# Altium schematic sheet Sheet2.SchDoc
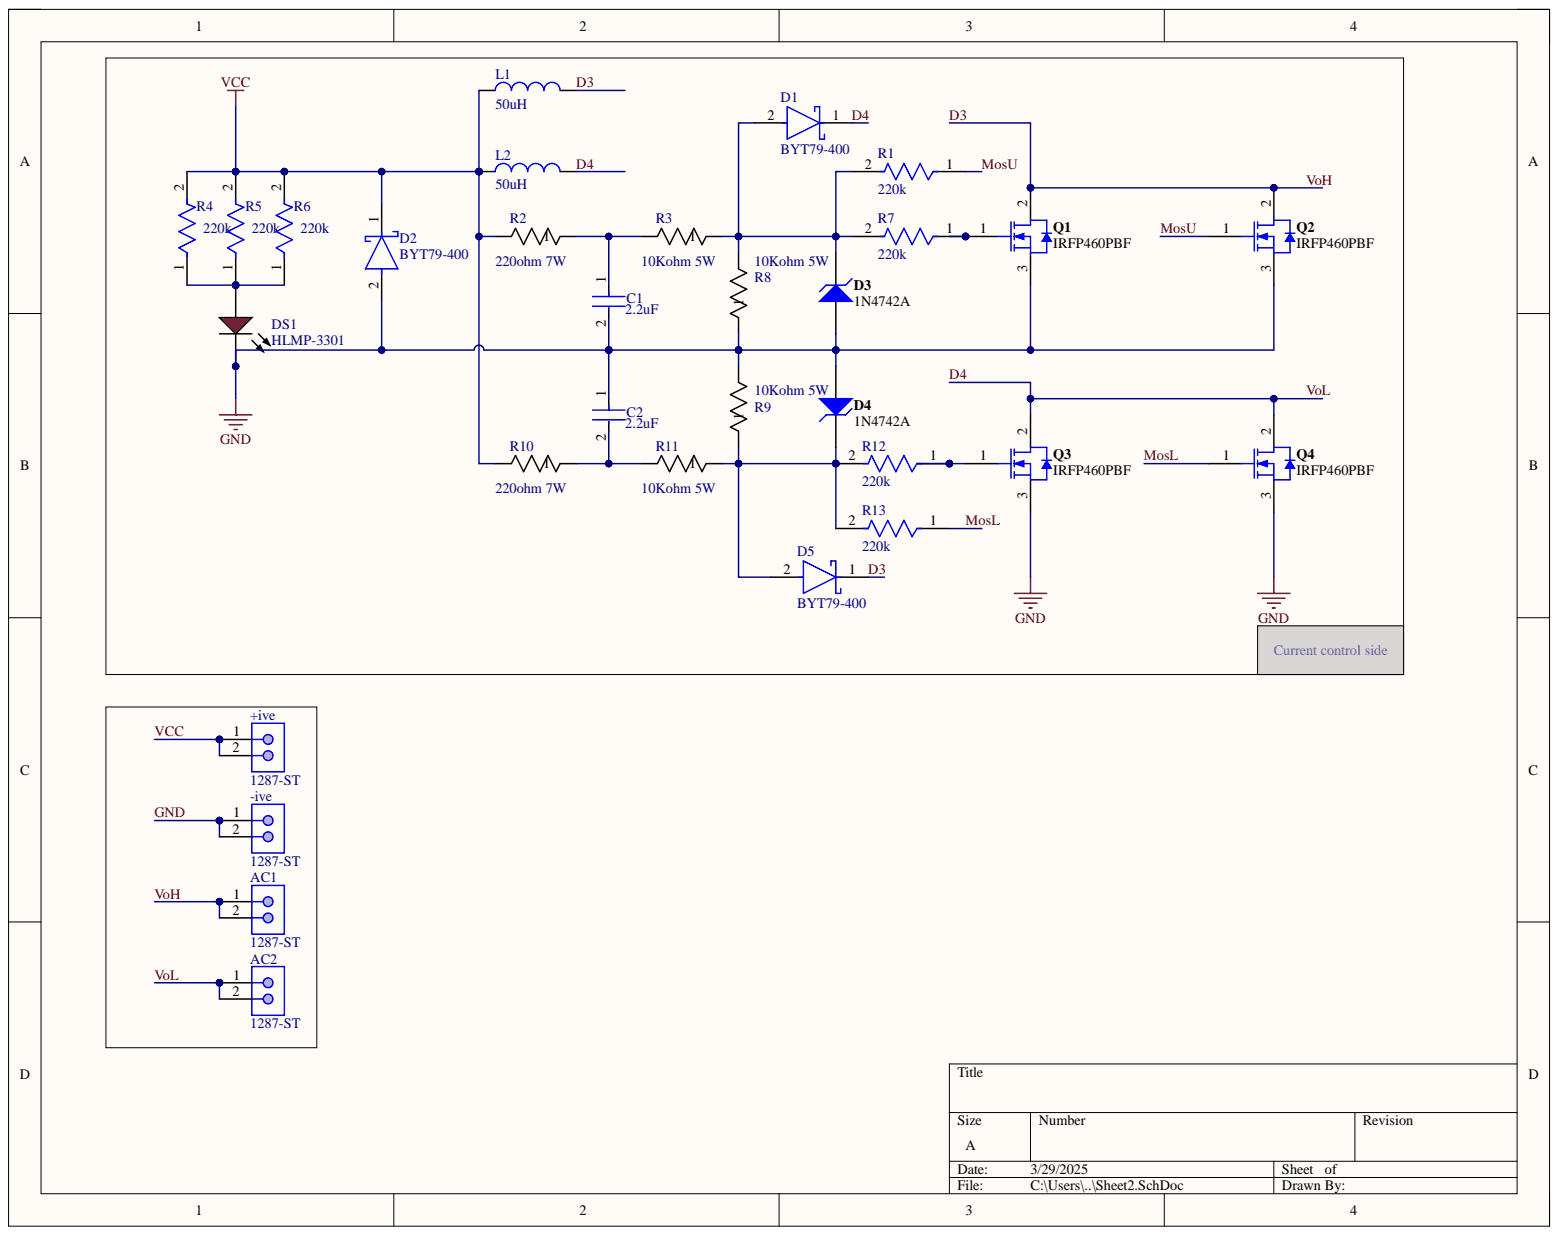
[diagram p0: Sheet2.SchDoc]

## Parts
+ive  1287-ST  [KSTN-1287-ST]
-ive  1287-ST  [KSTN-1287-ST]
AC1  1287-ST  [KSTN-1287-ST]
AC2  1287-ST  [KSTN-1287-ST]
C1  2.2uF  [FP-ECQ-E6223KF9-MFG]
C2  2.2uF  [FP-ECQ-E6223KF9-MFG]
D1  BYT79-400  [FP-TO-220-2-MFG]
D2  BYT79-400  [FP-TO-220-2-MFG]
D3  1N4742A  [FAIR-DO-41-2_V]
D4  1N4742A  [FAIR-DO-41-2_V]
D5  BYT79-400  [FP-TO-220-2-MFG]
DS1  HLMP-3301  [AVAG-HLMP-3301-2]
L1  50uH  [7447071]
L2  50uH  [7447071]
Q1  IRFP460PBF  [VISH-TO-247AC-3]
Q2  IRFP460PBF  [VISH-TO-247AC-3]
Q3  IRFP460PBF  [VISH-TO-247AC-3]
Q4  IRFP460PBF  [VISH-TO-247AC-3]
R1  220k  [FP-VR37-MFG]
R2  220ohm 7W  Max Power Dissipation: 7W  [RES_AC05_VIS]
R3  10Kohm 5W  Max Power Dissipation: 5W  [RES_AC05_VIS]
R4  220k  [FP-VR37-MFG]
R5  220k  [FP-VR37-MFG]
R6  220k  [FP-VR37-MFG]
R7  220k  [FP-VR37-MFG]
R8  10Kohm 5W  Max Power Dissipation: 5W  [RES_AC05_VIS]
R9  10Kohm 5W  [RES_AC05_VIS]
R10  220ohm 7W  Max Power Dissipation: 7W  [RES_AC05_VIS]
R11  10Kohm 5W  Max Power Dissipation: 5W  [RES_AC05_VIS]
R12  220k  [FP-VR37-MFG]
R13  220k  [FP-VR37-MFG]

## Nets
D3: AC1.1 AC1.2 D5.1 L1.2 Q1.2 Q2.2
D4: AC2.1 AC2.2 D1.1 L2.2 Q3.2 Q4.2
GND: -ive.1 -ive.2 C1.2 C2.1 D2.2 D3.1 D4.1 DS1.1 Q1.3 Q2.3 Q3.3 Q4.3 R8.1 R9.2
MosL: Q4.1 R13.1
MosU: Q2.1 R1.1
VCC: +ive.1 +ive.2 D2.1 L1.1 L2.1 R2.2 R4.2 R5.2 R6.2 R10.2
NetC1_1: C1.1 R2.1 R3.2
NetC2_2: C2.2 R10.1 R11.2
NetD1_2: D1.2 D3.2 R1.2 R3.1 R7.2 R8.2
NetD4_2: D4.2 D5.2 R9.1 R11.1 R12.2 R13.2
NetDS1_2: DS1.2 R4.1 R5.1 R6.1
NetQ1_1: Q1.1 R7.1
NetQ3_1: Q3.1 R12.1

## Library data of the parts
+ive -ive AC1 AC2 = CMP-2000-05485-1: PC Sturdi-Mount Quick-Fit Terminal, Vertical, Male, 2-Pin THD, Bulk; Manufacturer: Keystone; Angle: Straight; Finish: Tin; Finish Plating: TinPlate; Insulation Type: Non-Insulated; Material, Contact: Brass; Mounting Hole Size: 0.052; Primary Type: QuickDisconnect; Standards: ULListed; Tab Fit: 0.25; Component Kind: Standard; Contact Plating: Tin; Datasheet URL: http://www.keyelco.com/product-pdf.cfm?p=1859; Datasheet Version: Rev. C, 08/2012; Diameter, Hole: 0.052in.; Gender: Male; Length, Overall: 0.552"; Library Name: Altium Content Vault; Manufacturer URL: http://www.keyelco.com/; Material: .032[.81]Brass; Mount Angle: Straight; Mounting: VERTICAL; Mounting Technology: ThroughHole; Orientation: VERTICAL-Sturdi-Mount; Package Description: 2-Leads Quick-Fit Terminal, Pitch 5.08 mm; Package Reference: 1287-ST; Package URL: http://www.keyelco.com/product-pdf.cfm?p=1859; Terminal Style: PCBMale; Terminal Type: Quick-Fit; Termination: Solder; Type: Tab; Width, Overall: 0.312"
C1 C2 = CMP-00287-17062091-1: ECQ-E6223KF9 Cap Film 0.022uF 630V PET 10% (12 X 5.3 X 15.5mm) Radial 5mm 105°C T/R; Capacitance: 22nF; Dielectric Material: Polyester; Lead Diameter: 600µm; Case/Package: Radial; Component Kind: Standard; Depth: 5.3mm; Dielectric: PET; Height: 10.5mm; Height - Seated (Max): 15.494mm; Lead Pitch: 5mm; Length: 12mm; Library Name: Altium Content Vault; Max Operating Temperature: 105°C; Min Operating Temperature: -40°C; Mount: Through Hole; NexarPartId: 17062091; Radiation Hardening: No; RoHS: Compliant; Termination: Radial; Tolerance: 10%; Voltage Rating: 630V; Voltage Rating (DC): 630V; Width: 5.3086mm
D1 D2 D5 = CMP-240925-000090-2: DIODE SCHOTTKY 600V 6A TO220-2; Average Rectified Current: 19A; Element Configuration: Single; Forward Current: 30A; Forward Voltage: 1.8V; Max Forward Surge Current (Ifsm): 70A; Max Power Dissipation: 79W; Max Repetitive Reverse Voltage (Vrrm): 400V; Max Reverse Current: 50uA; Reverse Recovery Time: 0ns; Case/Package: TO-220; Component Kind: Standard; Height: 15.621mm; Length: 10.41mm; Library Name: Altium Content Vault; Max Operating Temperature: 175°C; Max Reverse Voltage (DC): 600V; Min Operating Temperature: -55°C; Mount: Through Hole; Number of Pins: 2; Package Quantity: 50; Packaging: Rail/Tube; Peak Non-Repetitive Surge Current: 70A; Radiation Hardening: No; RoHS Compliant: Yes; Series: Z-Rec®; Width: 4.699mm
D3 D4 = CMP-0892-00001-1: Zener Diode, 12V, 1W, 5%, -65 to 200 degC, 2-Pin DO-41, Body 2.72 x 5.2 mm, RoHS; Manufacturer: Fairchild Semiconductor; IR (uA): 5; PD (W): 1; Test Current IZ (mA): 21; VZ (Max.) (V): 12.6; VZ (Min.) (V): 11.4; ZZ (Max) (ohm): 8; Component Kind: Standard; ComponentLink1Description: Manufacturer URL; ComponentLink1URL: http://www.fairchildsemi.com/; ComponentLink2Description: Datasheet; ComponentLink2URL: http://www.fairchildsemi.com/ds/1N/1N4744A.pdf; ComponentLink3Description: Package Specification; ComponentLink3URL: http://www.fairchildsemi.com/dwg/DO/DO41A.pdf; DatasheetVersion: Rev. H3; Library Name: Altium Content Vault; Mounting Technology: Through Hole; Operating and Storage Temperature Range: -65 to 200 degC; PackageDescription: 2-Pin Axial leaded, Body 2.72 x 5.2 mm, Lead Spread 25.4 mm; PackageReference: DO-41-2; PackageVersion: Rev. 2; PartNumber: 1N4742A; RoHS: TRUE; Tolerance: 5%
DS1 = CMP-2000-06411-1: T-1 3/4 (5 mm) Diffused LED Lamp, 1.9 V, -40 to 100 degC, 2-Pin THD, RoHS, Bulk; Manufacturer: Avago; Chip Material: GaP; DELETED: Compliant; Dominant Wave Length: 626nm; Emitting Color: Red; Forward Current: 25mA; Forward Voltage: 2.4V; High Brightness: No; Lens Type: ColoredDiffused; Lum Intensity: 5.4mcd; Main Category: StandardLED; Number of  Elements: 1; Peak Wavelength: 635nm; Power Dissipation: 85W; Product Depth (mm): 6.1mm; Product Height (mm): 9.19mm; Reverse Voltage: 5V; Shape Type: Circular; Test Current (It): 10mA; Type: Uni-Color; Viewing Angle: 60deg; Component Kind: Standard; Datasheet URL: http://www.avagotech.com/docs/AV02-1558EN; Datasheet Version: 03/2015; Lens Dimensions: 5.08x5.08x8.3mm; Library Name: Altium Content Vault; Luminous Intensity: 5.4mcd; Manufacturer URL: http://www.avagotech.com/; Mounting: ThroughHole; Mounting Technology: ThroughHole; Operating Temp Range: -40Cto100C; Operating Temperature Classification: Industrial; Package Description: 2-Pin Through Hole LED, Dia 5.85 mm; Package Reference: HLMP-3301; Package Type: T-13/4; Packaging: TapeandReel; Pin Count: 2; Product Length (mm): 6.1mm; Rad Hardened: No
L1 L2 = CMP-03913-22803376-1: 7447071 470 µH ±20% Ferrite, Iron Powder Leaded Inductor, 3A Idc, 110mO Rdc WE-FI; Core Material: Composite, Ferrite; DC Resistance (DCR): 110mΩ; Inductance: 50µH; Max DC Current: 25A; Pin Length: 25mm; Shielding: Unshielded; Test Frequency: 10kHz; Ambient Temperature Range High: 65°C; Ambient Temperature Range Low: -40°C; Case/Package: Radial; Component Kind: Standard; Current Rating: 3A; Depth: 13mm; Diameter: 25mm; Inductance Tolerance: 20%; Lead Pitch: 11mm; Length: 25mm; Library Name: Altium Content Vault; Max Operating Temperature: 105°C; Min Operating Temperature: -40°C; Mount: Through Hole, Wire; NexarPartId: 22803376; Number of Pins: 2; Packaging: Bulk; REACH SVHC: Unknown; RMS Current (Irms): 3A; RoHS: Compliant; Series Resistance: 110mΩ; Termination: Through Hole; Tolerance: 20%; Width: 13.4mm
Q1-Q4 = CMP-2000-06478-1: N-Channel Power MOSFET, 500 V, -55 to 150 degC, 3-Pin FM (TO-247), RoHS, Tube; Manufacturer: Vishay; Continuous Drain Current Id: 20A; Drain Source Voltage Vds: 500V; No. of Pins: 3Pins; On Resistance Rds(on): 0.27ohm; Power Dissipation Pd: 280W; Rds(on) Test Voltage Vgs: 10V; SVHC: ToBeAdvised; Threshold Voltage Vgs: 4V; Transistor Case Style: TO-247AC; Transistor Polarity: NChannel; Automotive Qualification Standard: -; Component Kind: Standard; Datasheet URL: https://datasheet.ciiva.com/17070/0900766b8079122f-17070730.pdf; Datasheet Version: Rev. A, 07/2008; Library Name: Altium Content Vault; Manufacturer URL: http://www.vishay.com/; Mounting Technology: ThroughHole; MSL: -; Operating Temperature Max: 150°C; Package Description: 3-Pin Flange Mount Package, Body 15.58 x 4.945 mm, Pitch 5.46 mm; Package Reference: TO-247AC-3; Product Range: -
R1 R4-R7 R12 R13 = CMP-02408-000054-1: RES 1.2M OHM 5% 1/2W AXIAL; Power Rating: 500mW; Resistance: 220Kohm; Temperature Coefficient: 200ppm/°C; Voltage Rating: 3.5kV; Case/Package: Conformal; Component Kind: Standard; Construction: Cylindrical; Contact Plating: Tin; Diameter: 4mm; Length: 10mm; Library Name: Altium Content Vault; Max Operating Temperature: 155°C; Military Standard: Not; Min Operating Temperature: -55°C; Mount: Through Hole; Packaging: Ammo Pack; Radiation Hardening: No; Reach SVHC Compliant: Yes; Resistance Tolerance: 5%; Resistor Type: Metal Film; Termination: Axial; Tolerance: 5%; Voltage Rating (DC): 3.5kV
R2 R10 = CMP-00030-44829128-1: AC05000001807JAC00 Resistor, 180 Milliohms, ± 5%, 5 W, Axial Leaded, Wirewound, General Purpose; Power Rating: 5W; Resistance: 220Ω; Temperature Coefficient: -80ppm/°C; Case/Package: Axial; Component Kind: Standard; Composition: Wirewound; Diameter: 7.5mm; Features: Flame Retardant Coating; Length: 18mm; Library Name: Altium Content Vault; Max Operating Temperature: 200°C; Military Standard: Not; Min Operating Temperature: -40°C; Mount: Through Hole; NexarPartId: 44829128; Number of Terminations: 2; Packaging: Tape & Box (TB); Radiation Hardening: No; RoHS: Compliant; Termination: Axial; Thickness: 7.493mm; Tolerance: 5%; Width: 7.49mm
R3 R8 R9 R11 = CMP-00030-44829128-1: AC05000001807JAC00 Resistor, 180 Milliohms, ± 5%, 5 W, Axial Leaded, Wirewound, General Purpose; Resistance: 10KΩ; Temperature Coefficient: -80ppm/°C; Case/Package: Axial; Component Kind: Standard; Composition: Wirewound; Diameter: 7.5mm; Features: Flame Retardant Coating; Length: 18mm; Library Name: Altium Content Vault; Max Operating Temperature: 200°C; Military Standard: Not; Min Operating Temperature: -40°C; Mount: Through Hole; NexarPartId: 44829128; Number of Terminations: 2; Packaging: Tape & Box (TB); Power Rating: 5W; Radiation Hardening: No; RoHS: Compliant; Termination: Axial; Thickness: 7.493mm; Tolerance: 5%; Width: 7.49mm
  R9: Max Power Dissipation: 5W
